# Altium schematic sheet USB To UART Converter.SchDoc
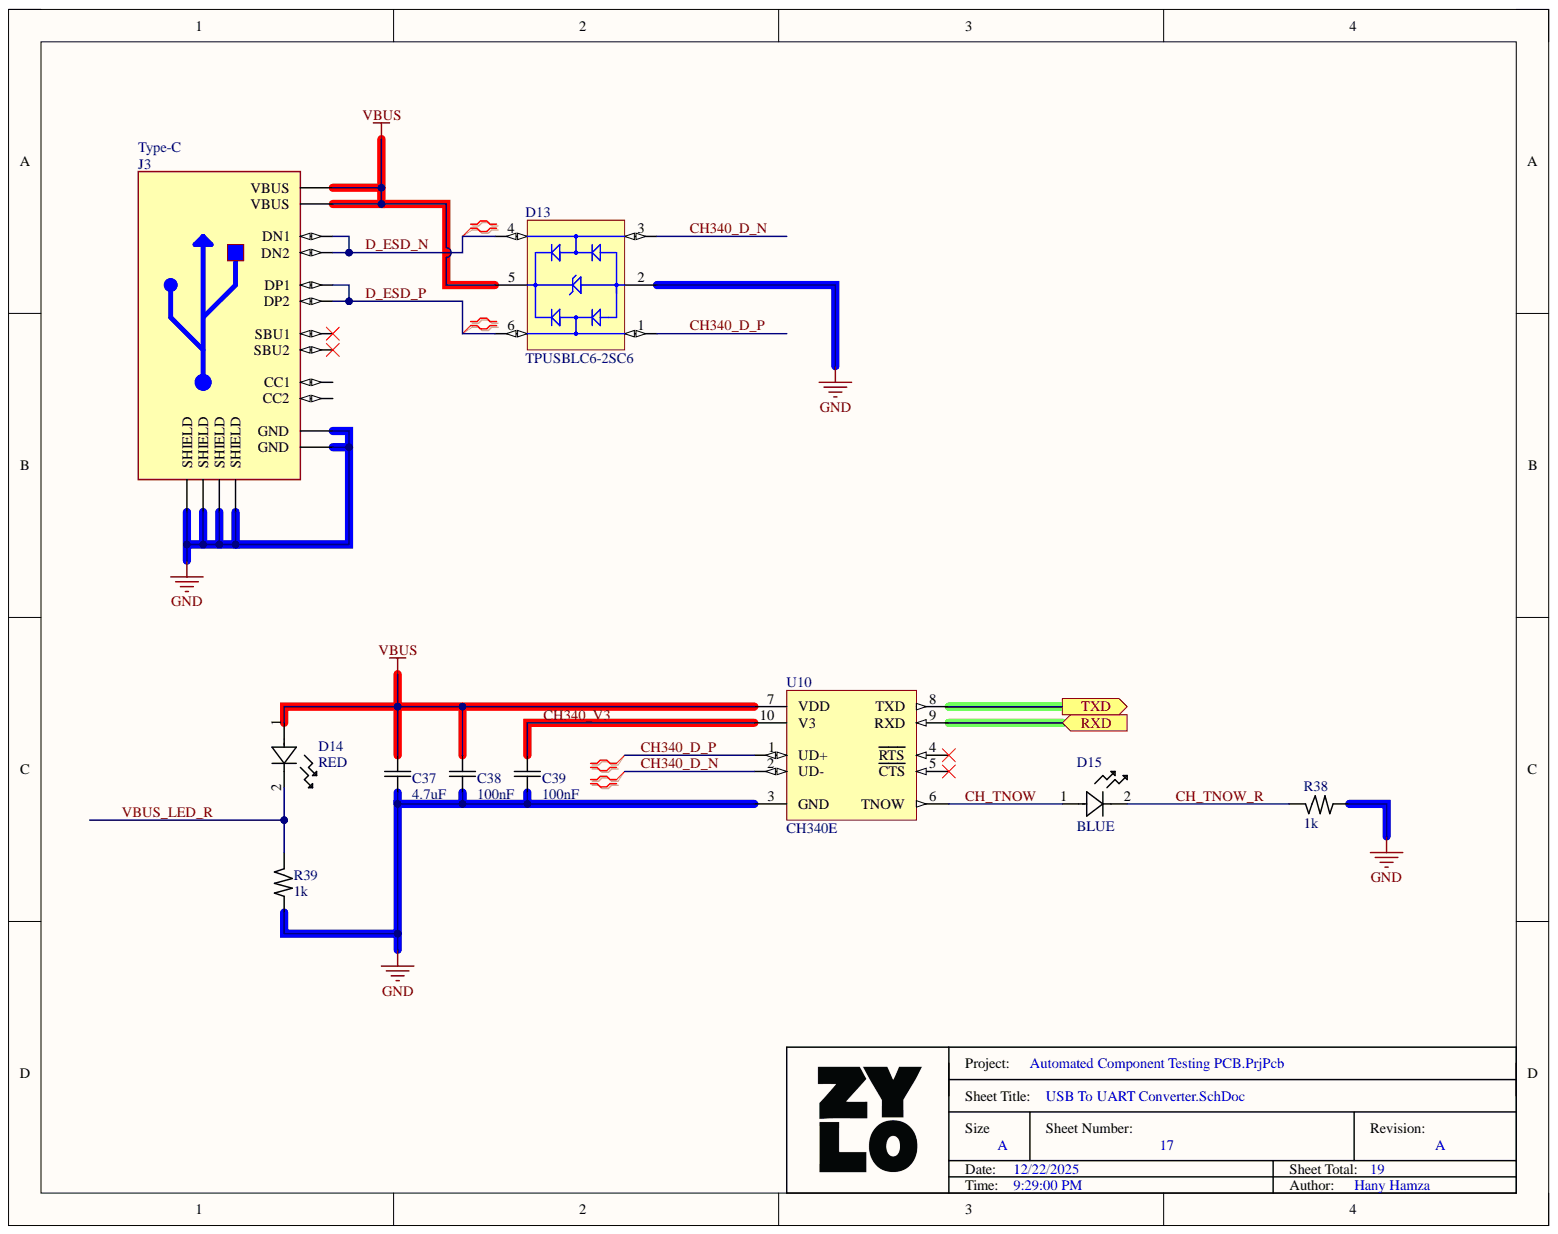
[diagram p0: USB To UART Converter.SchDoc]

## Parts
C37  4.7uF  [C0603]
C38  100nF  [C0603]
C39  100nF  [C0603]
D13  TPUSBLC6-2SC6  [SOT23-6]
D14  RED  [LED0805 RED]
D15  BLUE  [LED0805 BLUE]
J3  Type-C  [USB Type-C 16-Pin SMD]
R38  1k  [R0603]
R39  1k  [R0603]
U10  CH340E  [MSOP-10-NO EP]

## Ports
RXD, TXD

## Nets
GND: C37.1 C38.1 C39.1 D13.2 J3.A1_B12(GND) J3.B1_A12(GND) J3.S1(SHIELD) J3.S2(SHIELD) J3.S3(SHIELD) J3.S4(SHIELD) R38.1 R39.1 U10.3(GND)
VBUS: C37.2 C38.2 D13.5 D14.1 J3.A4_B9(VBUS) J3.B4_A9(VBUS) U10.7(VDD)
CH340_D_N: D13.3 U10.2(UD-)
CH340_D_P: D13.1 U10.1(UD+)
CH340_V3: C39.2 U10.10(V3)
CH_TNOW: D15.1 U10.6(TNOW)
CH_TNOW_R: D15.2 R38.2
D_ESD_N: D13.4 J3.A7(DN1) J3.B7(DN2)
D_ESD_P: D13.6 J3.A6(DP1) J3.B6(DP2)
RXD: U10.9(RXD) ; port RXD
TXD: U10.8(TXD) ; port TXD
VBUS_LED_R: D14.2 R39.2
NetJ3_A5: J3.A5(CC1)
NetJ3_A8: J3.A8(SBU1)
NetJ3_B5: J3.B5(CC2)
NetJ3_B8: J3.B8(SBU2)
NetU10_4: U10.4(RTS)
NetU10_5: U10.5(CTS)

## Library data of the parts
C37 = Cap_cer: 10V 4.7uF X7R ±10% 0603 Ceramic Capacitors ROHS; MF: Chinocera; MP: HGC0603R7475K100NTHJ; Component Kind: Standard; ComponentLink1Description: Datasheet; ComponentLink2Description: Supplier1; ComponentLink2URL: https://www.lcsc.com/product-detail/C49333234.html?s_z=n_HGC0603R7475K100NTHJ; Library Name: Components.IntLib
C38 C39 = Cap_cer: CAP CER 100nF 50V X7R 0603; MF: HRE; MP: CGA0603X7R104K500JT; Component Kind: Standard; ComponentLink1Description: Datasheet; ComponentLink1URL: https://www.lcsc.com/datasheet/C6119867.pdf; ComponentLink2Description: Supplier1; ComponentLink2URL: https://www.lcsc.com/product-detail/C6119867.html; Library Name: Components.IntLib
D13 = TPUSBLC6-2SC6: ESD DIODE 5VWM 15VC SOT-23-6; MF: TECH PUBLIC; Component Kind: Standard; ComponentLink1Description: Datasheet; ComponentLink1URL: https://www.lcsc.com/datasheet/C558442.pdf; ComponentLink2Description: Supplier1; ComponentLink2URL: https://www.lcsc.com/product-detail/C558442.html?s_z=n_C558442; Library Name: Components.IntLib; MP: TPUSBLC6-2SC6
D14 = LED: LED RED WATER CLEAR 0805 SMD; MF: XINGLIGHT; MP: XL-TD2012SURC; Component Kind: Standard; ComponentLink1Description: Datasheet; ComponentLink1URL: https://www.lcsc.com/datasheet/C5349939.pdf; ComponentLink2Description: Supplier1; ComponentLink2URL: https://www.lcsc.com/product-detail/C5349939.html; Library Name: Components.IntLib
D15 = LED: 20mA 500mcd~1200mcd 470nm Top-mount Blue 70mW 2.7V~3.2V 0805 LED Indication - Discrete RoHS; MF: XINGLIGHT; MP: XL-TD2012UBC; Component Kind: Standard; ComponentLink1Description: Datasheet; ComponentLink1URL: https://www.lcsc.com/datasheet/C5349940.pdf; ComponentLink2Description: Supplier1; ComponentLink2URL: https://www.lcsc.com/product-detail/C5349940.html; Library Name: Components.IntLib
J3 = USB Type-C 16-Pin SMD: CONN RCPT Type-C 16POS SMD R/A; MF: DEALON; MP: USB-TYPE-C-019; Component Kind: Standard; ComponentLink1Description: Datasheet; ComponentLink1URL: https://www.lcsc.com/datasheet/C2927039.pdf; ComponentLink2Description: Supplier1; ComponentLink2URL: https://www.lcsc.com/product-detail/C2927039.html?s_z=n_C2927039; Library Name: Connectors.IntLib
R38 R39 = Res: RES 1kΩ ±5% 100mW 0603; MF: VO; MP: SCR0603J1K; Component Kind: Standard; ComponentLink1Description: Datasheet; ComponentLink1URL: https://www.lcsc.com/datasheet/C3017736.pdf; ComponentLink2Description: Supplier1; ComponentLink2URL: https://www.lcsc.com/product-detail/C3017736.html; Library Name: Components.IntLib
U10 = CH340E: USB to Serial Port Chip CH340; MF: WCH; Component Kind: Standard; ComponentLink1Description: Datasheet; ComponentLink1URL: https://www.lcsc.com/datasheet/C99652.pdf; ComponentLink2Description: Supplier1; ComponentLink2URL: https://www.lcsc.com/product-detail/C99652.html?s_z=n_ch340; Library Name: Components.IntLib; MP: CH340E
